# Altium schematic sheet Connectors.SchDoc(Connectors)
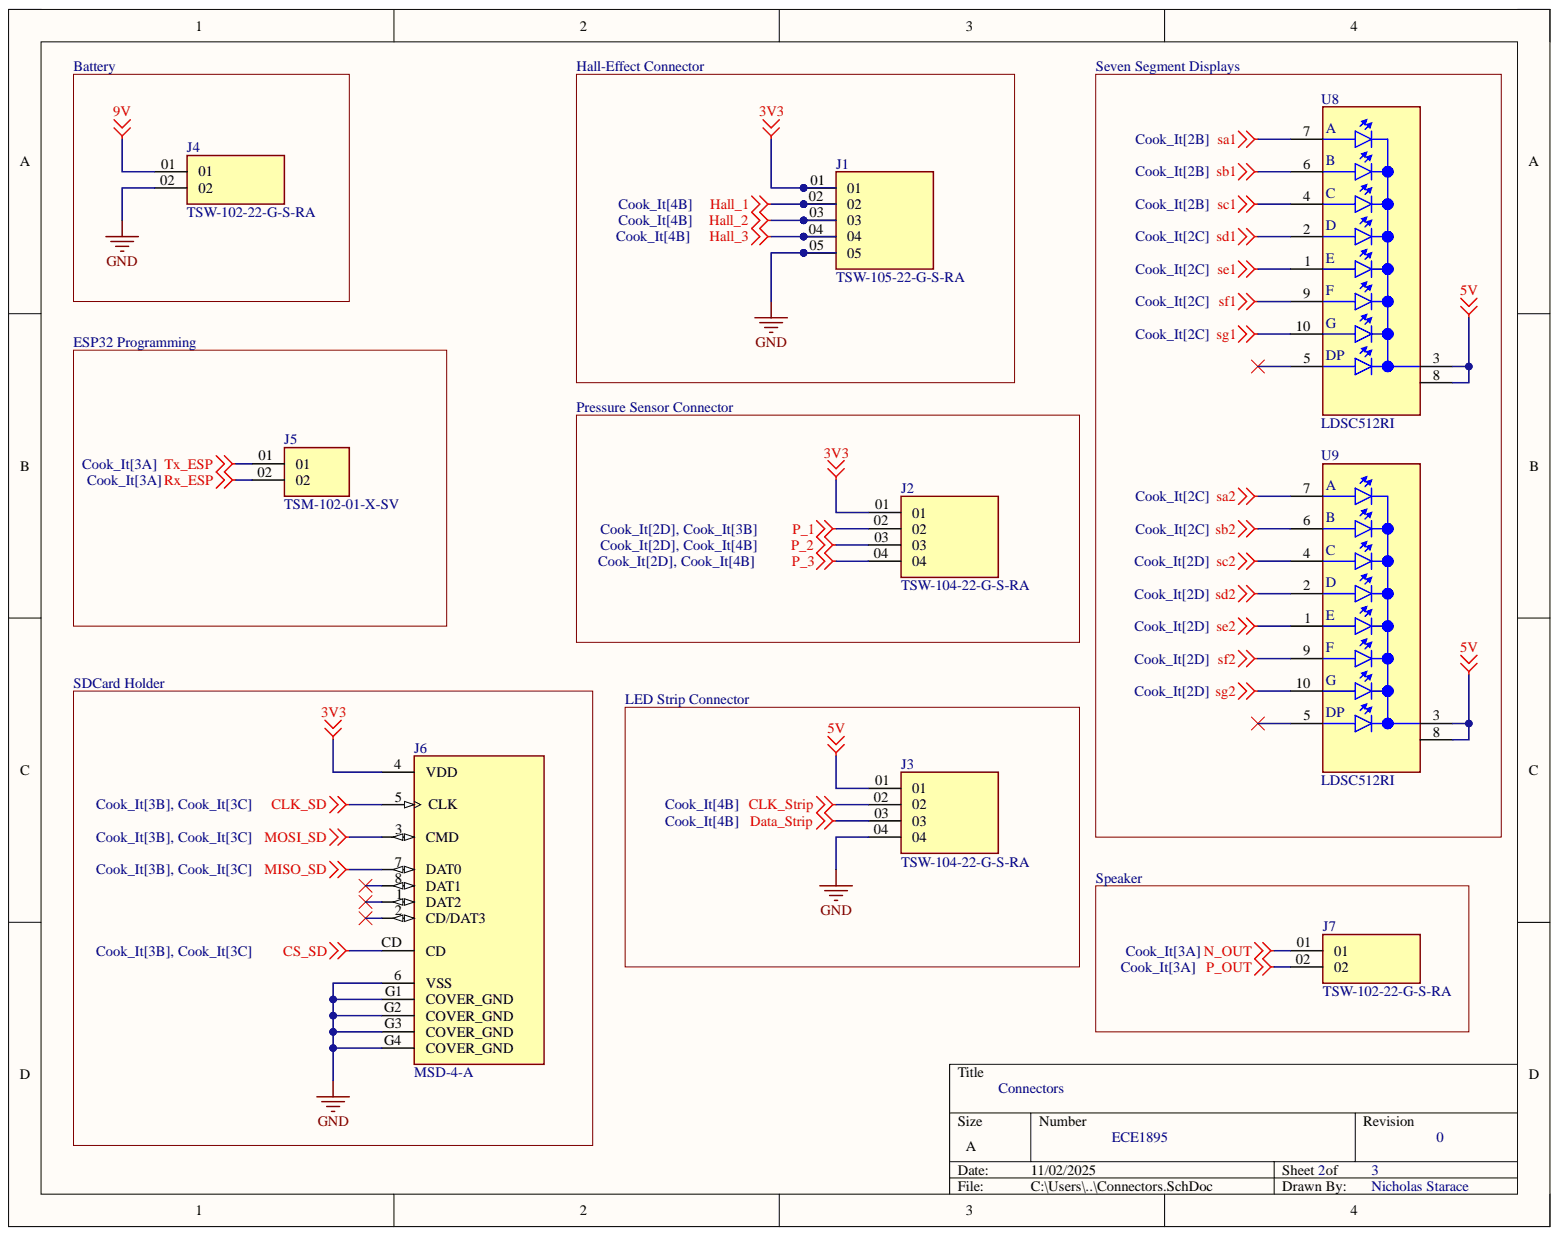
[diagram p0: Connectors.SchDoc]

## Parts
J1  TSW-105-22-G-S-RA  [SAMTEC_TSW-105-22-G-S-RA]
J2  TSW-104-22-G-S-RA  [SAMTEC_TSW-104-22-G-S-RA]
J3  TSW-104-22-G-S-RA  [SAMTEC_TSW-104-22-G-S-RA]
J4  TSW-102-22-G-S-RA  [SAMTEC_TSW-102-22-G-S-RA]
J5  TSM-102-01-X-SV  [SAMTEC_TSM-102-01-X-SV]
J6  MSD-4-A  [CUI_MSD-4-A]
J7  TSW-102-22-G-S-RA  [SAMTEC_TSW-102-22-G-S-RA]
U8  LDSC512RI  [LED_LDSC512RI]
U9  LDSC512RI  [LED_LDSC512RI]

## Nets
3V3: J1.01 J2.01 J6.4(VDD)
5V: J3.01 U8.3 U8.8 U9.3 U9.8
9V: J4.01
CLK_SD: J6.5(CLK)
CLK_Strip: J3.02
CS_SD: J6.CD
Data_Strip: J3.03
GND: J1.05 J3.04 J4.02 J6.6(VSS) J6.G1(COVER_GND) J6.G2(COVER_GND) J6.G3(COVER_GND) J6.G4(COVER_GND)
Hall_1: J1.02
Hall_2: J1.03
Hall_3: J1.04
MISO_SD: J6.7(DAT0)
MOSI_SD: J6.3(CMD)
N_OUT: J7.01
P_1: J2.02
P_2: J2.03
P_3: J2.04
P_OUT: J7.02
Rx_ESP: J5.02
sa1: U8.7
sa2: U9.7
sb1: U8.6
sb2: U9.6
sc1: U8.4
sc2: U9.4
sd1: U8.2
sd2: U9.2
se1: U8.1
se2: U9.1
sf1: U8.9
sf2: U9.9
sg1: U8.10
sg2: U9.10
Tx_ESP: J5.01

Not connected: J6 1(DAT2) 2(CD/DAT3) 8(DAT1); U8 5; U9 5

## Library data of the parts
J1 = TSW-105-22-G-S-RA: Conn Unshrouded Header HDR 5 POS 2.54mm Solder RA Side Entry Thru-Hole Bulk; MF: Samtec; Availability: In Stock; Check_prices: https://www.snapeda.com/parts/TSW-105-22-G-S-RA/Samtec/view-part/?ref=eda; Component Kind: Standard; Library Name: TSW-105-22-G-S-RA.IntLib; MP: TSW-105-22-G-S-RA; Package: None; Price: None; Purchase-URL: https://pricing.snapeda.com/search/part/TSW-105-22-G-S-RA/?ref=eda; SnapEDA_Link: https://www.snapeda.com/parts/TSW-105-22-G-S-RA/Samtec/view-part/?ref=snap
J2 J3 = TSW-104-22-G-S-RA: MF: Samtec; Availability: Unavailable; Component Kind: Standard; Library Name: TSW-104-22-G-S-RA.IntLib; MP: TSW-104-22-G-S-RA; Package: None; Price: None
J4 J7 = TSW-102-22-G-S-RA: MF: Samtec; Availability: Unavailable; Component Kind: Standard; Library Name: TSW-102-22-G-S-RA.IntLib; MP: TSW-102-22-G-S-RA; Package: None; Price: None
J5 = TSM-102-01-X-SV: TSM - Samtec 2.54mm Single Row Vertical Surface Mount Header 5.84mm Post Height (01) - Fast Stock; MP: TSM-102-01-L-SV; Check_prices: https://www.snapeda.com/parts/TSM-102-01-L-SV/Samtec+Inc./view-part/?ref=eda; Component Kind: Standard; Library Name: TSM-102-01-X-SV.IntLib; MF: Samtec; Package: None; SnapEDA_Link: https://www.snapeda.com/parts/TSM-102-01-L-SV/Samtec+Inc./view-part/?ref=snap
J6 = MSD-4-A: Micro SD Card Connector, 9 Positions, Connector and Ejector, Push In, Auto Eject Out, Surface Mount, 1.8 mm Height Above Board, Gold Flash; MF: Same Sky; Check_prices: https://www.snapeda.com/parts/MSD-4-A/Same+Sky/view-part/?ref=eda; Component Kind: Standard; Library Name: MSD-4-A.IntLib; MP: MSD-4-A; Package: None; SnapEDA_Link: https://www.snapeda.com/parts/MSD-4-A/Same+Sky/view-part/?ref=snap
U8 U9 = LDSC512RI: .56IN. 7 SEGMENT SINGLE DISPLAY, 565NM GREEN, GRAY FACE, WHITE SEGMENTS, COMMON | Lumex LDS-C512RI; Check_prices: https://www.snapeda.com/parts/LDS-C512RI/Lumex/view-part/?ref=eda; Component Kind: Standard; Library Name: LDSC512RI.IntLib; MF: Lumex; MP: LDS-C512RI; Package: None; SnapEDA_Link: https://www.snapeda.com/parts/LDS-C512RI/Lumex/view-part/?ref=snap
